# Altium schematic sheet AIRZAI_BASE_BD_REVC Sheet1.SchDoc
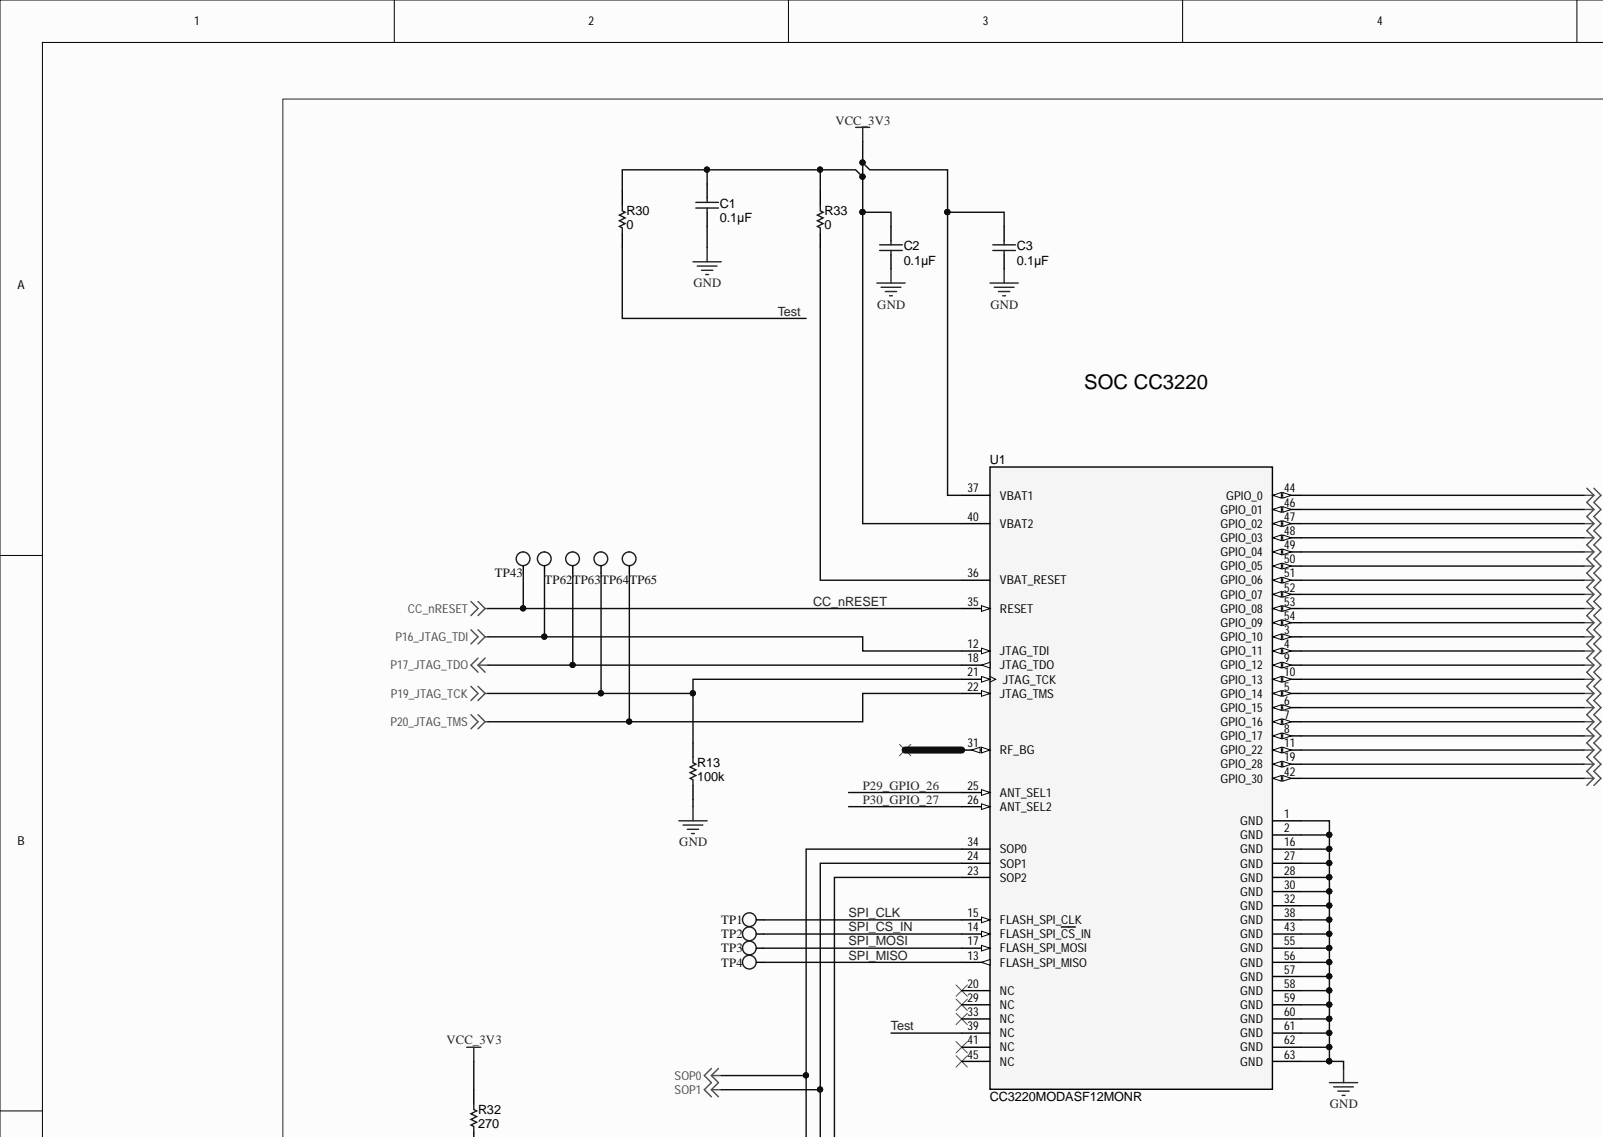
[diagram p0: AIRZAI_BASE_BD_REVC Sheet1.SchDoc, top left]
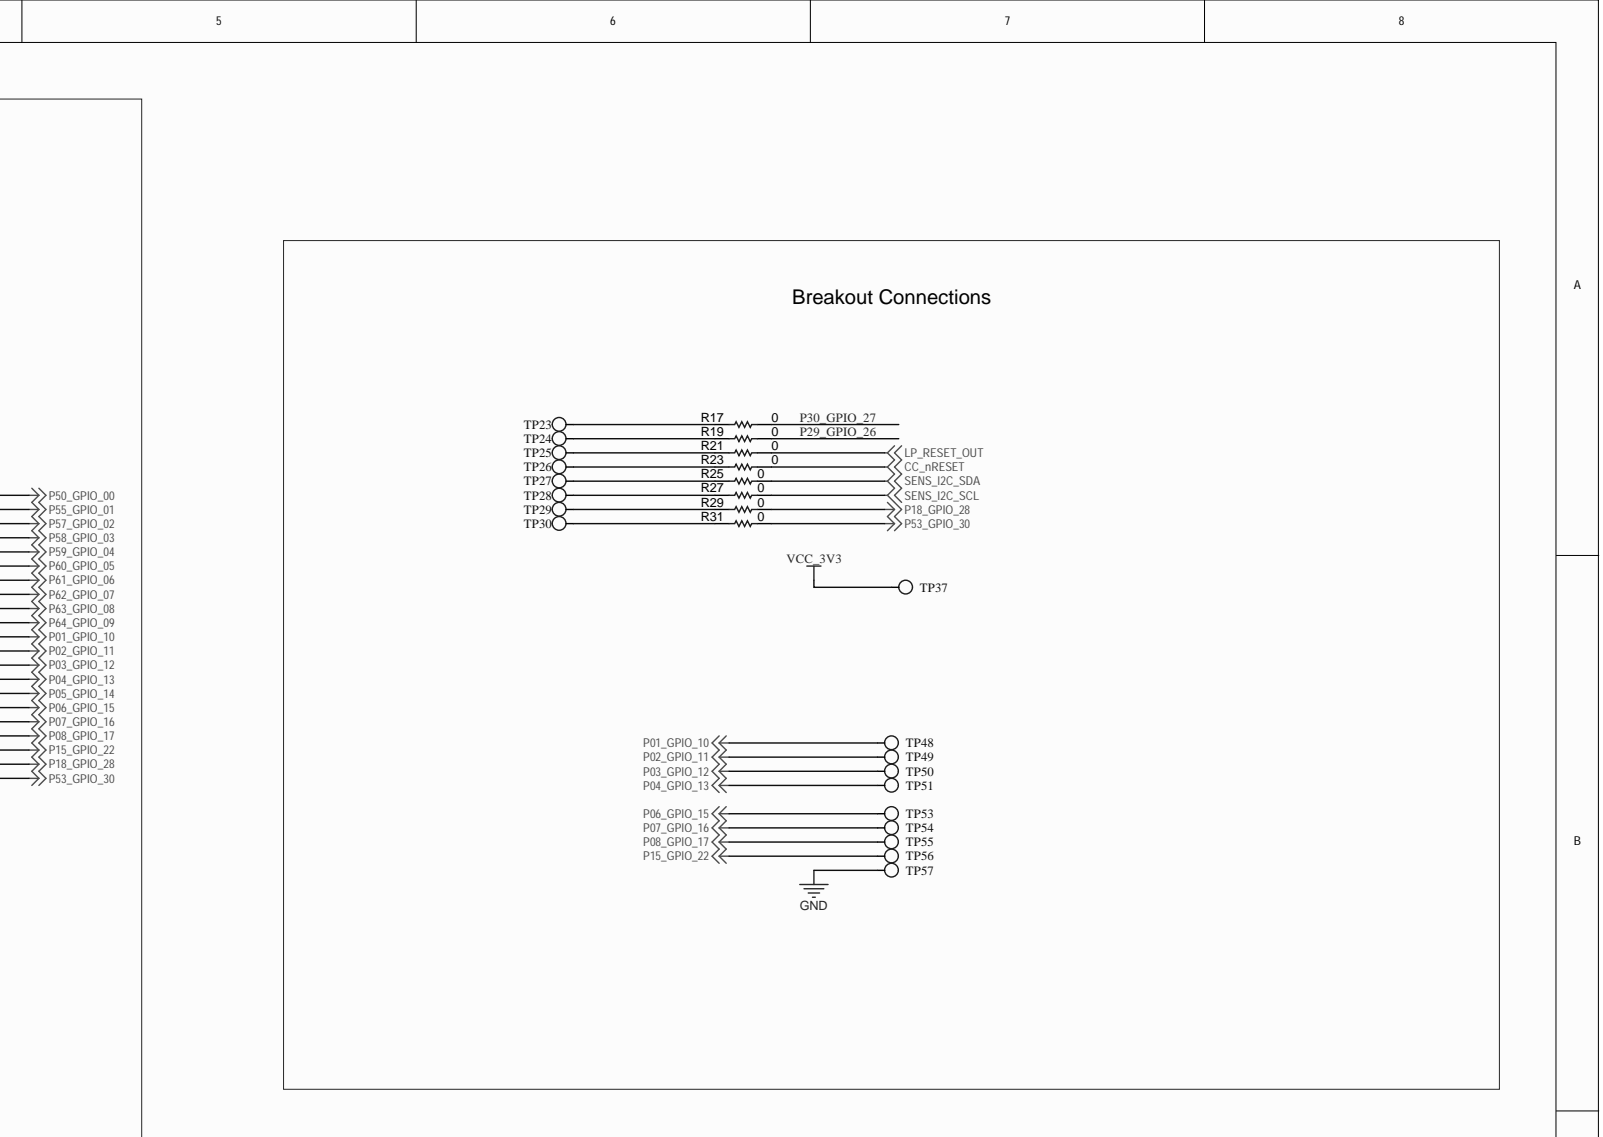
[diagram p1: AIRZAI_BASE_BD_REVC Sheet1.SchDoc, top right]
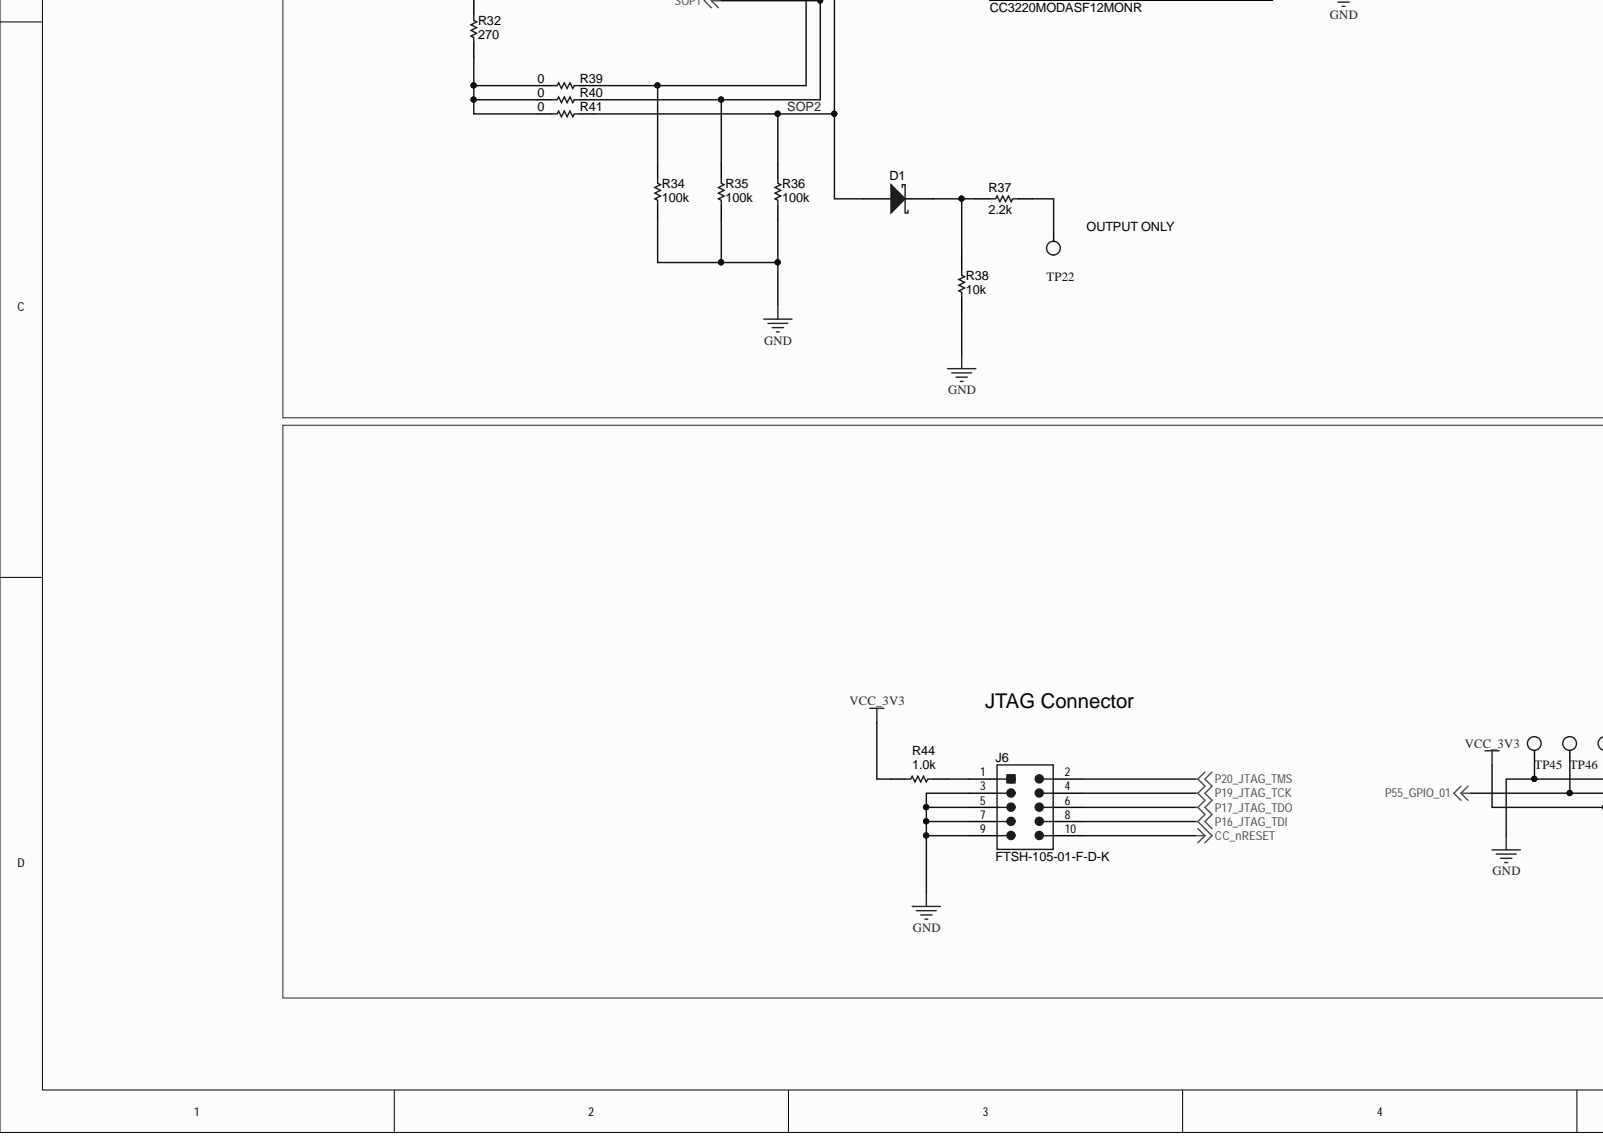
[diagram p2: AIRZAI_BASE_BD_REVC Sheet1.SchDoc, bottom left]
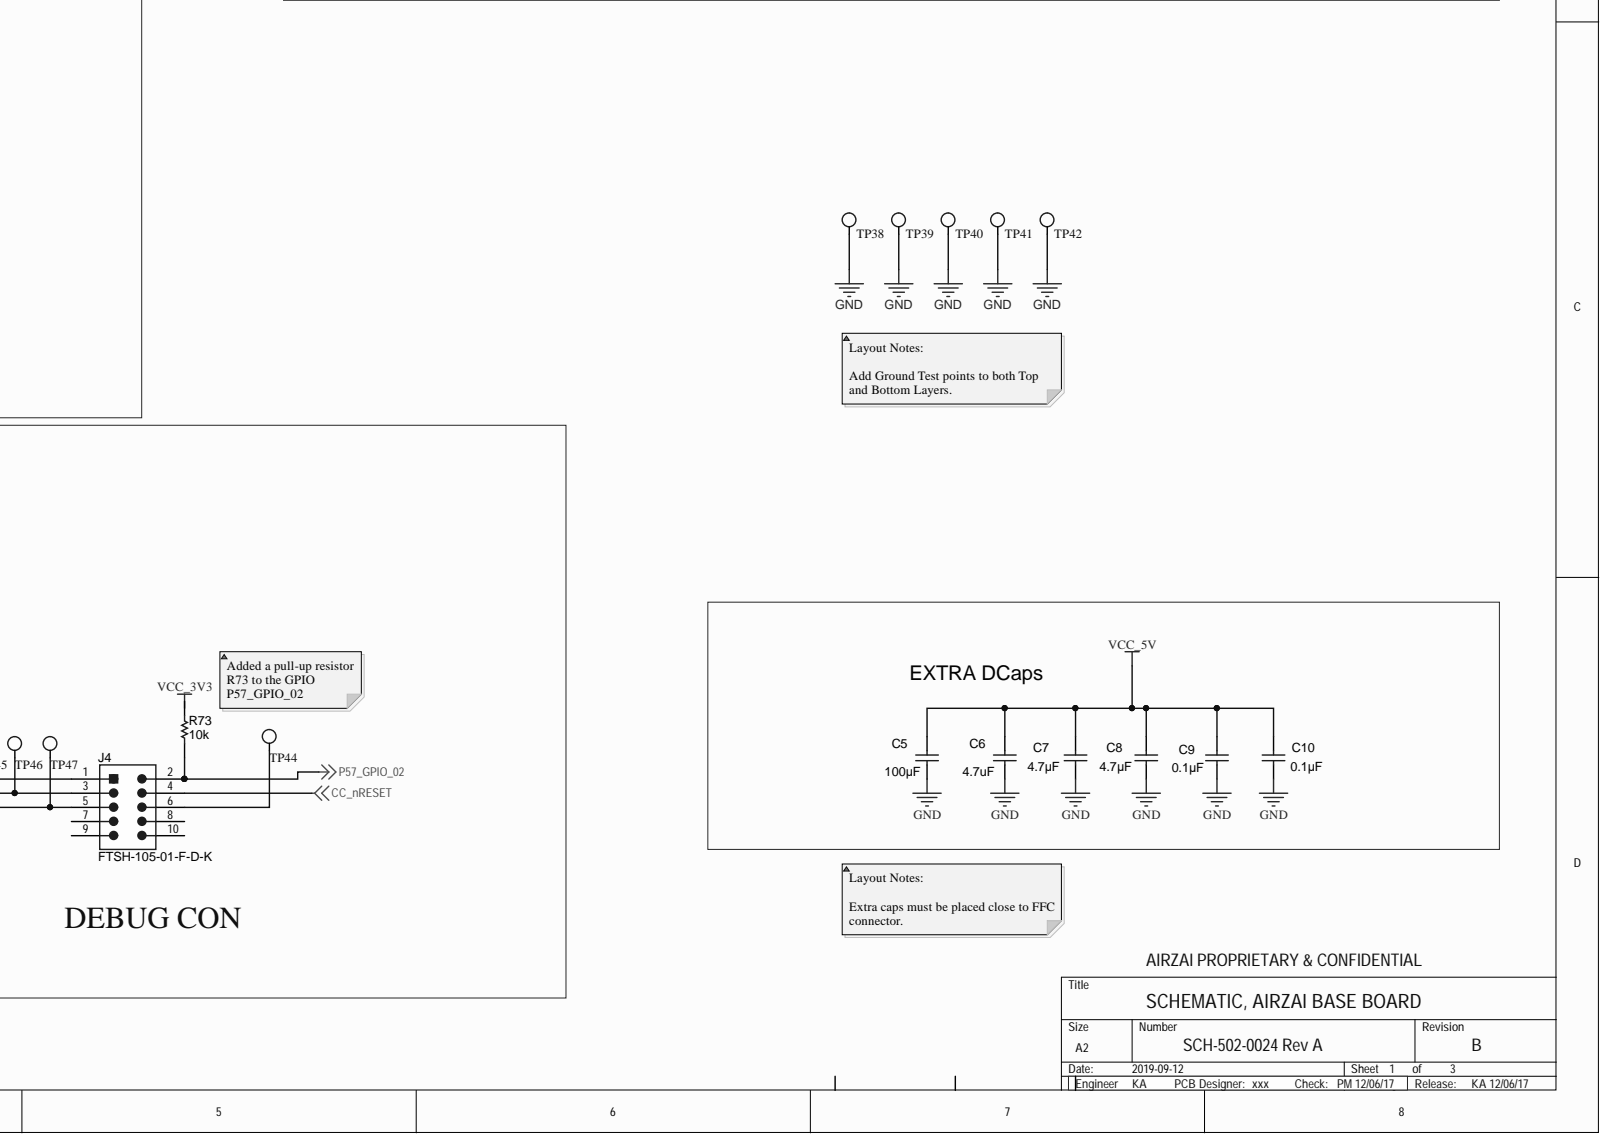
[diagram p3: AIRZAI_BASE_BD_REVC Sheet1.SchDoc, bottom right]

## Parts
C1  ValueDisplayed: 0.1µF  [0402]  (hidden comment: GRM155R61A104KA01D)
C2  ValueDisplayed: 0.1µF  [0402]  (hidden comment: GRM155R61A104KA01D)
C3  ValueDisplayed: 0.1µF  [0402]  (hidden comment: GRM155R61A104KA01D)
C5  ValueDisplayed: 100µF  [1206_180]  (hidden comment: GRM31CR60J107ME39L)
C6  value 4.7uF  [SM0402_BTD.1SM_ACT_H55_CAP_WSS]  (hidden comment: C1005X5R0J475M050BC)
C7  ValueDisplayed: 4.7µF  [SM0402_BTD.1SM_ACT_H55_CAP_WSS]  (hidden comment: C1005X5R0J475M050BC)
C8  ValueDisplayed: 4.7µF  [SM0402_BTD.1SM_ACT_H55_CAP_WSS]  (hidden comment: C1005X5R0J475M050BC)
C9  ValueDisplayed: 0.1µF  [SM0402_BTD.1SM_ACT_H55_CAP_WSS]  (hidden comment: C1005X5R1A104K050BA)
C10  ValueDisplayed: 0.1µF  [SM0402_BTD.1SM_ACT_H55_CAP_WSS]  (hidden comment: C1005X5R1A104K050BA)
D1  CD0603-B00340  [Diode_0603]  (value not drawn)
J4  FTSH-105-01-F-D-K  [Samtec_FTSH-105-01-x-D-K]
J6  FTSH-105-01-F-D-K  [Samtec_FTSH-105-01-x-D-K]
R13  value 100k  [0402]  (hidden comment: CRCW0402100KJNED)
R17  value 0  [0402]  (hidden comment: ERJ-2GE0R00X)
R19  value 0  [0402]  (hidden comment: ERJ-2GE0R00X)
R21  value 0  [0402]  (hidden comment: ERJ-2GE0R00X)
R23  value 0  [0402]  (hidden comment: ERJ-2GE0R00X)
R25  value 0  [0402]  (hidden comment: ERJ-2GE0R00X)
R27  value 0  [0402]  (hidden comment: ERJ-2GE0R00X)
R29  value 0  [0402]  (hidden comment: ERJ-2GE0R00X)
R30  value 0  [0603]  (hidden comment: RC0603JR-070RL)
R31  value 0  [0402]  (hidden comment: ERJ-2GE0R00X)
R32  value 270  [0402]  (hidden comment: CRCW0402270RJNED)
R33  value 0  [0603]  (hidden comment: RC0603JR-070RL)
R34  value 100k  [0402]  (hidden comment: CRCW0402100KJNED)
R35  value 100k  [0402]  (hidden comment: CRCW0402100KJNED)
R36  value 100k  [0402]  (hidden comment: CRCW0402100KJNED)
R37  value 2.2k  [0402]  (hidden comment: CRCW04022K20JNED)
R38  value 10k  [0402]  (hidden comment: CRCW040210K0JNED)
R39  value 0  [0603]  (hidden comment: RC0603JR-070RL)
R40  value 0  [0603]  (hidden comment: RC0603JR-070RL)
R41  value 0  [0603]  (hidden comment: RC0603JR-070RL)
R44  value 1.0k  [0402]  (hidden comment: CRCW04021K00JNED)
R73  value 10k  [0402]  (hidden comment: CRCW040210K0JNED)
TP1  WIREPT  [TP_0.9MM]  (value not drawn)
TP2  WIREPT  [TP_0.9MM]  (value not drawn)
TP3  WIREPT  [TP_0.9MM]  (value not drawn)
TP4  WIREPT  [TP_0.9MM]  (value not drawn)
TP22  WIREPT  [TP_0.9MM]  (value not drawn)
TP23  WIREPT  [TP_0.9MM]  (value not drawn)
TP24  WIREPT  [TP_0.9MM]  (value not drawn)
TP25  WIREPT  [TP_0.9MM]  (value not drawn)
TP26  WIREPT  [TP_0.9MM]  (value not drawn)
TP27  WIREPT  [TP_0.9MM]  (value not drawn)
TP28  WIREPT  [TP_0.9MM]  (value not drawn)
TP29  WIREPT  [TP_0.9MM]  (value not drawn)
TP30  WIREPT  [TP_0.9MM]  (value not drawn)
TP37  WIREPT  [TP_0.9MM]  (value not drawn)
TP38  WIREPT  [TP_0.9MM]  (value not drawn)
TP39  WIREPT  [TP_0.9MM]  (value not drawn)
TP40  WIREPT  [TP_0.9MM]  (value not drawn)
TP41  WIREPT  [TP_0.9MM]  (value not drawn)
TP42  WIREPT  [TP_0.9MM]  (value not drawn)
TP43  WIREPT  [TP_0.9MM]  (value not drawn)
TP44  WIREPT  [TP_0.9MM]  (value not drawn)
TP45  WIREPT  [TP_0.9MM]  (value not drawn)
TP46  WIREPT  [TP_0.9MM]  (value not drawn)
TP47  WIREPT  [TP_0.9MM]  (value not drawn)
TP48  WIREPT  [TP_0.9MM]  (value not drawn)
TP49  WIREPT  [TP_0.9MM]  (value not drawn)
TP50  WIREPT  [TP_0.9MM]  (value not drawn)
TP51  WIREPT  [TP_0.9MM]  (value not drawn)
TP53  WIREPT  [TP_0.9MM]  (value not drawn)
TP54  WIREPT  [TP_0.9MM]  (value not drawn)
TP55  WIREPT  [TP_0.9MM]  (value not drawn)
TP56  WIREPT  [TP_0.9MM]  (value not drawn)
TP57  WIREPT  [TP_0.9MM]  (value not drawn)
TP62  WIREPT  [TP_0.9MM]  (value not drawn)
TP63  WIREPT  [TP_0.9MM]  (value not drawn)
TP64  WIREPT  [TP_0.9MM]  (value not drawn)
TP65  WIREPT  [TP_0.9MM]  (value not drawn)
U1  CC3220MODASF12MONR  [MON0063A]

## Nets
GND: C1.2 C2.2 C3.2 C5.2 C6.2 C7.2 C8.2 C9.2 C10.2 J4.1 J6.3 J6.5 J6.7 J6.9 R13.2 R34.1 R35.2 R36.2 R38.2 TP38.1 TP39.1 TP40.1 TP41.1 TP42.1 TP45.1 TP57.1 U1.1(GND) U1.2(GND) U1.16(GND) U1.27(GND) U1.28(GND) U1.30(GND) U1.32(GND) U1.38(GND) U1.43(GND) U1.55(GND) U1.56(GND) U1.57(GND) U1.58(GND) U1.59(GND) U1.60(GND) U1.61(GND) U1.62(GND) U1.63(GND)
LP_RESET_OUT: R21.2
P01_GPIO_10: TP48.1 U1.3(GPIO_10)
P02_GPIO_11: TP49.1 U1.4(GPIO_11)
P03_GPIO_12: TP50.1 U1.9(GPIO_12)
P04_GPIO_13: TP51.1 U1.10(GPIO_13)
P05_GPIO_14: U1.5(GPIO_14)
P06_GPIO_15: TP53.1 U1.6(GPIO_15)
P07_GPIO_16: TP54.1 U1.7(GPIO_16)
P08_GPIO_17: TP55.1 U1.8(GPIO_17)
P15_GPIO_22: TP56.1 U1.11(GPIO_22)
P16_JTAG_TDI: J6.8 TP62.1 U1.12(JTAG_TDI)
P17_JTAG_TDO: J6.6 TP63.1 U1.18(JTAG_TDO)
P18_GPIO_28: R29.2 U1.19(GPIO_28)
P19_JTAG_TCK: J6.4 R13.1 TP64.1 U1.21(JTAG_TCK)
P20_JTAG_TMS: J6.2 TP65.1 U1.22(JTAG_TMS)
P50_GPIO_00: U1.44(GPIO_0)
P53_GPIO_30: R31.2 U1.42(GPIO_30)
P55_GPIO_01: J4.3 TP46.1 U1.46(GPIO_01)
P57_GPIO_02: J4.2 R73.2 U1.47(GPIO_02)
P58_GPIO_03: U1.48(GPIO_03)
P59_GPIO_04: U1.49(GPIO_04)
P60_GPIO_05: U1.50(GPIO_05)
P61_GPIO_06: U1.51(GPIO_06)
P62_GPIO_07: U1.52(GPIO_07)
P63_GPIO_08: U1.53(GPIO_08)
P64_GPIO_09: U1.54(GPIO_09)
SENS_I2C_SCL: R27.2
SENS_I2C_SDA: R25.2
SOP0: R34.2 R39.1 U1.34(SOP0)
SOP1: R35.1 R40.1 U1.24(SOP1)
VCC_3V3: C1.1 C2.1 C3.1 J4.5 R30.2 R32.1 R33.2 R44.1 TP37.1 TP47.1 U1.37(VBAT1) U1.40(VBAT2)
VCC_5V: C5.1 C6.1 C7.1 C8.1 C9.1 C10.1
CC_nRESET: J4.4 J6.10 R23.2 TP43.1 U1.35(RESET)
P29_GPIO_26: R19.2 U1.25(ANT_SEL1)
P30_GPIO_27: R17.2 U1.26(ANT_SEL2)
SOP2: D1.2 R36.1 R41.1 U1.23(SOP2)
SPI_CLK: TP1.1 U1.15(FLASH_SPI_CLK)
SPI_CS_IN: TP2.1 U1.14(FLASH_SPI_CS_IN)
SPI_MISO: TP4.1 U1.13(FLASH_SPI_MISO)
SPI_MOSI: TP3.1 U1.17(FLASH_SPI_MOSI)
Test: R30.1 U1.39(NC)
NetD1_1: D1.1 R37.1 R38.1
NetJ4_6: J4.6 TP44.1
NetJ4_7: J4.7
NetJ4_8: J4.8
NetJ4_9: J4.9
NetJ4_10: J4.10
NetJ6_1: J6.1 R44.2
NetR17_1: R17.1 TP23.1
NetR19_1: R19.1 TP24.1
NetR21_1: R21.1 TP25.1
NetR23_1: R23.1 TP26.1
NetR25_1: R25.1 TP27.1
NetR27_1: R27.1 TP28.1
NetR29_1: R29.1 TP29.1
NetR31_1: R31.1 TP30.1
NetR32_2: R32.2 R39.2 R40.2 R41.2
NetR33_1: R33.1 U1.36(VBAT_RESET)
NetR37_2: R37.2 TP22.1
NetR73_1: R73.1
NetU1_20: U1.20(NC)
NetU1_29: U1.29(NC)
NetU1_31: U1.31(RF_BG)
NetU1_33: U1.33(NC)
NetU1_41: U1.41(NC)
NetU1_45: U1.45(NC)

## Library data of the parts
C1-C3 = GRM155R61A104KA01D: CAP, CERM, 0.1 µF, 10 V, +/- 10%, X5R, 0402; Manufacturer: MuRata; AEC Category: Q200; Cap_F: 0.0000001; CompType: Capacitor; Polarized: N; SizeArea_mm2: 0.5; SizeAreaWB_mm2: 3; SizeX_mm: 1; SizeZ_mm: 0.6; TaMax_C: 85; TaMin_C: -55; Tolerance_%: 10; U/M: EA; Value: 0.1uF; Value1: Unknown; Value2: 10V; AEC Qualified: ?; Cap_Case: 0402; Component Kind: Standard; ComponentLink1Description: Datasheet link; ComponentLink1URL: http://search.murata.co.jp/Ceramy/PnsearchViewAction.do?HnOFG=ON&HnNFG=ON&SEL_CNT=50&sLang=en&sKey=GRM155R61A104KA01D&x=9&y=9&search=startwith; LatestRevisionDate: 2016-08-14; Library Name: Capacitor_Ceramic.IntLib; MountType: SMT; PackageReference: 0402; PartNumber: GRM155R61A104KA01D; Published: 2008-06-19; RoHS: Y; Signal Integrity: Cap; Simulation: Cap; SizeY_mm: 0.5; Supplier 1: Digi-Key; Supplier 2: Mouser; Supplier 3: Farnell; Supplier Part Number 1: 490-1318-1-ND; Supplier Part Number 2: 81-GRM155R61A104KA01; Supplier Part Number 3: 1828862; SymbolVersion: 2008-07-09; Technology: Ceramic; TempCo: X5R; VDC_V: 10; Publisher: Texas Instruments
C5 = GRM31CR60J107ME39L: CAP, CERM, 100 µF, 6.3 V, +/- 20%, X5R, 1206; Manufacturer: MuRata; AEC Category: Q200; Cap_Case: 1206; Cap_F: 0.0001; CompType: Capacitor; ESR_ohm: 0.003; Polarized: N; SizeArea_mm2: 5.12; SizeAreaWB_mm2: 10.92; SizeX_mm: 3.2; SizeY_mm: 1.6; SizeZ_mm: 1.8; TaMax_C: 85; TaMin_C: -55; Tolerance_%: 20; U/M: EA; Value: 100uF; Value1: 0.003 ohm; Value2: 6.3V; VDC_V: 6.3; AEC Qualified: ?; Component Kind: Standard; ComponentLink1Description: Datasheet link; ComponentLink1URL: http://search.murata.co.jp/Ceramy/PnsearchViewAction.do?HnOFG=ON&HnNFG=ON&SEL_CNT=50&sLang=en&sKey=GRM31CR60J107ME39L&x=9&y=9&search=startwith; LatestRevisionDate: 2016-07-02; Library Name: Capacitor_Ceramic.IntLib; MountType: SMT; PackageReference: 1206; PartNumber: GRM31CR60J107ME39L; Published: 2008-06-19; RoHS: Y; Signal Integrity: Cap; Simulation: Cap; Supplier 1: Digi-Key; Supplier 2: Mouser; Supplier 3: Farnell; Supplier Part Number 1: 490-4539-1-ND; Supplier Part Number 2: 81-GRM31CR60J107ME9L; Supplier Part Number 3: 1735535; SymbolVersion: 2008-07-09; Technology: Ceramic; TempCo: X5R; Publisher: Texas Instruments
C6 = C1005X5R0J475M050BC: CAP, CERM, 4.7 µF, 6.3 V, +/- 20%, X5R, 0402; Manufacturer: TDK; Component Kind: Standard; Library Name: Capacitor_Ceramic.IntLib; PartNumber: C1005X5R0J475M050BC
C7 C8 = C1005X5R0J475M050BC: CAP, CERM, 4.7 µF, 6.3 V, +/- 20%, X5R, 0402; Manufacturer: TDK; Cap_Case: 0402; Cap_F: 0.0000047; CompType: Capacitor; Polarized: N; TaMax_C: 85; TaMin_C: -55; Tolerance_%: 20; U/M: EA; Value: 4.7uF; Value1: Unknown; Value2: 6.3V; VDC_V: 6.3; Component Kind: Standard; ComponentLink1Description: Datasheet link; ComponentLink1URL: http://www.tdk.com/pdf/TDKMLCCCapRange.pdf; LatestRevisionDate: 2015-02-23; Library Name: Capacitor_Ceramic.IntLib; MountType: SMT; PackageReference: 0402; PartNumber: C1005X5R0J475M050BC; Published: 2008-06-19; RoHS: Y; Supplier 1: Digi-Key; Supplier 2: Farnell; Supplier 3: Newark; Supplier Part Number 1: 445-7395-1-ND; Supplier Part Number 2: 1962109; Supplier Part Number 3: 48T2906; SymbolVersion: 2008-07-09; Technology: Ceramic; TempCo: X5R; Publisher: Texas Instruments
C9 = C1005X5R1A104K050BA: CAP, CERM, 0.1 µF, 10 V, +/- 10%, X5R, 0402; Manufacturer: TDK; Cap_Case: 0402; Cap_F: 0.0000001; CompType: Capacitor; Polarized: N; TaMax_C: 85; TaMin_C: -55; Tolerance_%: 10; U/M: EA; Value: 0.1uF; Value1: Unknown; Value2: 10V; Component Kind: Standard; ComponentLink1Description: Datasheet link; ComponentLink1URL: http://product.tdk.com/en/catalog/datasheets/mlcc_commercial_general_en.pdf; LatestRevisionDate: 2015-02-23; Library Name: Capacitor_Ceramic.IntLib; MountType: SMT; PackageReference: 0402; PartNumber: C1005X5R1A104K050BA; Published: 2008-06-19; RoHS: Y; Supplier 1: Digi-Key; Supplier 2: Mouser; Supplier 3: Farnell; Supplier Part Number 1: 445-1265-1-ND; Supplier Part Number 2: 810-C1005X5R1A104K; Supplier Part Number 3: 2368215; SymbolVersion: 2008-07-09; Technology: Ceramic; TempCo: X5R; VDC_V: 10; Publisher: Texas Instruments
C10 = C1005X5R1A104K050BA: CAP, CERM, 0.1 µF, 10 V, +/- 10%, X5R, 0402; Manufacturer: TDK; Cap_Case: SM0402_BTD.1SM_ACT; Cap_F: 0.0000001; CompType: Capacitor; Polarized: N; TaMax_C: 85; TaMin_C: -55; Tolerance_%: 10; U/M: EA; Value: 0.1uF; Value1: Unknown; Value2: 10V; Component Kind: Standard; ComponentLink1Description: Datasheet link; ComponentLink1URL: http://product.tdk.com/en/catalog/datasheets/mlcc_commercial_general_en.pdf; LatestRevisionDate: 2015-02-23; Library Name: Capacitor_Ceramic.IntLib; MountType: SMT; PackageReference: 0402; PartNumber: C1005X5R1A104K050BA; Published: 2008-06-19; RoHS: Y; Supplier 1: Digi-Key; Supplier 2: Mouser; Supplier 3: Farnell; Supplier Part Number 1: 445-1265-1-ND; Supplier Part Number 2: 810-C1005X5R1A104K; Supplier Part Number 3: 2368215; SymbolVersion: 2008-07-09; Technology: Ceramic; TempCo: X5R; VDC_V: 10; Publisher: Texas Instruments
D1 = CD0603-B00340: Diode, Schottky, 45 V, 0.03 A, 0603 Diode; Manufacturer: Bourns; AEC Category: Q101; Io_A: 0.03; SizeArea_mm2: 1.53; SizeAreaWB_mm2: 5.13; SizeX_mm: 1.7; SizeY_mm: 0.9; SizeZ_mm: 0.75; TJmax_C: 125; U/M: EA; Value: 45V; Value1: 0.03A; Value2: 0.37V; Vf_V: 0.37; Vr_V: 45; AEC Qualified: ?; Component Kind: Standard; ComponentLink1Description: Datasheet link; ComponentLink1URL: http://www.bourns.com/pdfs/SBCD0603_1005.pdf; CompType: Diode; LatestRevisionDate: 2016-07-03; Library Name: Diode.IntLib; MountType: SMT; PackageReference: 0603 Diode; PartNumber: CD0603-B00340; Published: 2008-06-26; RoHS: Y; Signal Integrity: Diode; Supplier 1: Mouser; Supplier 2: Farnell; Supplier Part Number 1: 652-CD0603-B00340; Supplier Part Number 2: 1456534; SymbolVersion: 2008-12-08; Type: Schottky; Publisher: Texas Instruments
J4 J6 = FTSH-105-01-F-D-K: Header, 1.27 mm, 5x2, Gold, TH; Manufacturer: Samtec; Gender: Male; Pitch_mm: 1.27; Row_Count: 2; Shrouded: Y; SymbolCreator: a0412235; Color: Black; Component Kind: Standard; ComponentLink1Description: Datasheet URL; ComponentLink1URL: https://www.samtec.com/ftppub/cpdf/FTSH-1XX-XX-XXX-D-XX-MKT.pdf; CompType: Header; ContactFinish: Gold; LatestRevisionDate: 2016-07-01; Library Name: Connectors_Samtec.IntLib; MountType: TH; Orientation: Vertical; PackageReference: Header, 1.27 mm, 5x2, TH; PartNumber: FTSH-105-01-F-D-K; PinCount: 10; RoHS: Y; RowSpacing_mm: 1.27; Supplier 1: Digi-Key; Supplier Part Number 1: FTSH-105-01-F-D-K-ND; SymbolVersion: 2013-12-22; Publisher: Texas Instruments
R13 R34-R36 = CRCW0402100KJNED: RES, 100 k, 5%, 0.063 W, 0402; Manufacturer: Vishay-Dale; AEC Category: Q200; CompType: Resistor; EIA_Code: E24; Power_W: 0.063; Res_ohm: 100000; SizeArea_mm2: 0.5; SizeX_mm: 1; SizeZ_mm: 0.6; TempCo: 200 ppm/C; Tolerance_%: 5; U/M: EA; Value2: 0.063W; VDC_V: 50; AEC Qualified: ?; Component Kind: Standard; ComponentLink1Description: Datasheet link; ComponentLink1URL: http://www.vishay.com/docs/20035/dcrcwe3.pdf; LatestRevisionDate: 2016-07-01; Library Name: Resistor_0402.IntLib; MountType: SMT; PackageReference: 0402; PartNumber: CRCW0402100KJNED; Published: 2008-06-26; RoHS: Y; Signal Integrity: Resistor; Simulation: Resistor; SizeY_mm: 0.5; Supplier 1: Digi-Key; Supplier 2: Mouser; Supplier 3: Farnell; Supplier Part Number 1: 541-100KJCT-ND; Supplier Part Number 2: 71-CRCW0402J-100K-E3; Supplier Part Number 3: 1652738; SymbolVersion: 2009-05-29; Technology: Thick Film; Value1: 5%; Value_Code: 100k; ValueDisplayed: 100k; Publisher: Texas Instruments
R17 R19 R21 R23 R25 R27 R29 R31 = ERJ-2GE0R00X: RES, 0, 5%, 0.063 W, 0402; Manufacturer: Panasonic; AEC Category: Q200; CompType: Resistor; EIA_Code: E24; Power_W: 0.063; SizeArea_mm2: 0.5; SizeX_mm: 1; SizeZ_mm: 0.6; TempCo: 600 ppm/C; Tolerance_%: 5; U/M: EA; Value2: 0.063W; Value_Code: 0000; VDC_V: 50; AEC Qualified: ?; Component Kind: Standard; ComponentLink1Description: Datasheet link; ComponentLink1URL: http://www.panasonic.com/industrial/components/pdf/AOA0000CE1.pdf; LatestRevisionDate: 2016-07-01; Library Name: Resistor_0402.IntLib; MountType: SMT; PackageReference: 0402; PartNumber: ERJ-2GE0R00X; Published: 2008-06-26; Res_ohm: 0; RoHS: Y; Signal Integrity: Resistor; Simulation: Resistor; SizeY_mm: 0.5; Supplier 1: Digi-Key; Supplier 2: Mouser; Supplier 3: Farnell; Supplier Part Number 1: P0.0JCT-ND; Supplier Part Number 2: 667-ERJ-2GE0R00X; Supplier Part Number 3: 2059190; SymbolVersion: 2009-05-29; Technology: Thick Film; Value1: 5%; ValueDisplayed: 0; Publisher: Texas Instruments
R30 R33 R39-R41 = ERJ-2GE0R00X: RES, 0, 5%, 0.1 W, 0603; Manufacturer: Yageo; Power Rating: 100mW; Resistance: 0mR; Temperature Coefficient: 200ppm/°C; Tolerance: 1%; Value2: 0.1W; Value_Code: 0000; VDC_V: 50; Voltage Rating: 50V; Case/Package: 0603; Case Code (Imperial): 0603; Case Code (Metric): 1608; Component Kind: Standard; ComponentLink1Description: Datasheet link; ComponentLink1URL: http://www.panasonic.com/industrial/components/pdf/AOA0000CE1.pdf; ComponentLink2Description: http://www.farnell.com/datasheets/1868787.pdf?src-supplier=Element14; ComponentLink2URL: http://www.farnell.com/datasheets/1868787.pdf?src-supplier=Element14; ComponentLink3Description: https://www.verical.com/server-webapp/html/getDatasheet?partID=329462&src-supplier=Verical; ComponentLink3URL: https://www.verical.com/server-webapp/html/getDatasheet?partID=329462&src-supplier=Verical; ComponentLink4Description: http://www.yageo.com/documents/recent/PYu-RC_Group_51_RoHS_L_9.pdf?src-supplier=Mouser; ComponentLink4URL: http://www.yageo.com/documents/recent/PYu-RC_Group_51_RoHS_L_9.pdf?src-supplier=Mouser; ComponentLink5Description: http://www.farnell.com/datasheets/443971.pdf?src-supplier=Element14; ComponentLink5URL: http://www.farnell.com/datasheets/443971.pdf?src-supplier=Element14; ComponentLink6Description: http://documents.rs-components.com/techlib://itc_doclist_GB.htm?stockno=6515918&src-supplier=RSComponents; ComponentLink6URL: http://documents.rs-components.com/techlib://itc_doclist_GB.htm?stockno=6515918&src-supplier=RSComponents; Composition: Thick Film; Contact Plating: Tin,Matte; Depth: 0.8mm; Height: 0.45mm; Lead Free: Lead Free; Length: 1.6mm; Library Name: Resistor_0402.IntLib; Manufacturer Part Number: RC0603JR-070RL; Max Operating Temperature: 155°C; Military Standard: Not; Min Operating Temperature: -55°C; Number of Pins: 2; Package Quantity: 5000; Packaging: Tape and Reel; PartNumber: RC0603JR-070RL; Pricing 1: 1=0.1, 10=0.021, 25=0.0152, 50=0.0114, 100=0.0084, 250=0.00644, 500=0.00514, 1000=0.00379 (USD); Radiation Hardening: No; REACH SVHC: No SVHC; Signal Integrity: Resistor; Simulation: Resistor; Stock 1: 47590704; Supplier 1: Digi-Key; Supplier Part Number 1: 311-0.0GRDKR-ND; Termination: SMD/SMT; Value1: 5%; ValueDisplayed: 0; Voltage Rating (DC): 50V; Width: 0.8mm
R32 = CRCW0402270RJNED: RES, 270, 5%, 0.063 W, 0402; Manufacturer: Vishay-Dale; AEC Category: Q200; CompType: Resistor; EIA_Code: E24; Power_W: 0.063; SizeArea_mm2: 0.5; SizeX_mm: 1; SizeZ_mm: 0.6; TempCo: 200 ppm/C; Tolerance_%: 5; U/M: EA; Value2: 0.063W; Value_Code: 270R; VDC_V: 50; AEC Qualified: ?; Component Kind: Standard; ComponentLink1Description: Datasheet link; ComponentLink1URL: http://www.vishay.com/docs/20035/dcrcwe3.pdf; LatestRevisionDate: 2016-07-01; Library Name: Resistor_0402.IntLib; MountType: SMT; PackageReference: 0402; PartNumber: CRCW0402270RJNED; Published: 2008-06-26; Res_ohm: 270; RoHS: Y; Signal Integrity: Resistor; Simulation: Resistor; SizeY_mm: 0.5; Supplier 1: Digi-Key; Supplier 2: Mouser; Supplier 3: Farnell; Supplier Part Number 1: 541-270JCT-ND; Supplier Part Number 2: 71-CRCW0402J-270-E3; Supplier Part Number 3: 1514691; SymbolVersion: 2009-05-29; Technology: Thick Film; Value1: 5%; ValueDisplayed: 270; Publisher: Texas Instruments
R37 = CRCW04022K20JNED: RES, 2.2 k, 5%, 0.063 W, 0402; Manufacturer: Vishay-Dale; AEC Category: Q200; CompType: Resistor; EIA_Code: E24; Power_W: 0.063; Res_ohm: 2200; SizeArea_mm2: 0.5; SizeX_mm: 1; SizeZ_mm: 0.6; TempCo: 200 ppm/C; Tolerance_%: 5; U/M: EA; Value2: 0.063W; Value_Code: 2k20; VDC_V: 50; AEC Qualified: ?; Component Kind: Standard; ComponentLink1Description: Datasheet link; ComponentLink1URL: http://www.vishay.com/docs/20035/dcrcwe3.pdf; LatestRevisionDate: 2016-07-01; Library Name: Resistor_0402.IntLib; MountType: SMT; PackageReference: 0402; PartNumber: CRCW04022K20JNED; Published: 2008-06-26; RoHS: Y; Signal Integrity: Resistor; Simulation: Resistor; SizeY_mm: 0.5; Supplier 1: Digi-Key; Supplier 2: Mouser; Supplier 3: Farnell; Supplier Part Number 1: 541-2.2KJCT-ND; Supplier Part Number 2: 71-CRCW0402J-2.2K-E3; Supplier Part Number 3: 1514678; SymbolVersion: 2009-05-29; Technology: Thick Film; Value1: 5%; ValueDisplayed: 2.2k; Publisher: Texas Instruments
R38 R73 = CRCW040210K0JNED: RES, 10 k, 5%, 0.063 W, 0402; Manufacturer: Vishay-Dale; AEC Category: Q200; CompType: Resistor; EIA_Code: E24; Power_W: 0.063; Res_ohm: 10000; SizeArea_mm2: 0.5; SizeX_mm: 1; SizeZ_mm: 0.6; TempCo: 200 ppm/C; Tolerance_%: 5; U/M: EA; Value2: 0.063W; Value_Code: 10k0; VDC_V: 50; AEC Qualified: ?; Component Kind: Standard; ComponentLink1Description: Datasheet link; ComponentLink1URL: http://www.vishay.com/docs/20035/dcrcwe3.pdf; LatestRevisionDate: 2016-07-01; Library Name: Resistor_0402.IntLib; MountType: SMT; PackageReference: 0402; PartNumber: CRCW040210K0JNED; Published: 2008-06-26; RoHS: Y; Signal Integrity: Resistor; Simulation: Resistor; SizeY_mm: 0.5; Supplier 1: Digi-Key; Supplier 2: Mouser; Supplier 3: Farnell; Supplier Part Number 1: 541-10KJCT-ND; Supplier Part Number 2: 71-CRCW0402J-10K-E3; Supplier Part Number 3: 1514663; SymbolVersion: 2009-05-29; Technology: Thick Film; Value1: 5%; ValueDisplayed: 10k; Publisher: Texas Instruments
R44 = CRCW04021K00JNED: RES, 1.0 k, 5%, 0.063 W, 0402; Manufacturer: Vishay-Dale; AEC Category: Q200; CompType: Resistor; EIA_Code: E24; Power_W: 0.063; Res_ohm: 1000; SizeArea_mm2: 0.5; SizeX_mm: 1; SizeZ_mm: 0.6; TempCo: 200 ppm/C; Tolerance_%: 5; U/M: EA; Value2: 0.063W; Value_Code: 1k00; VDC_V: 50; AEC Qualified: ?; Component Kind: Standard; ComponentLink1Description: Datasheet link; ComponentLink1URL: http://www.vishay.com/docs/20035/dcrcwe3.pdf; LatestRevisionDate: 2016-07-01; Library Name: Resistor_0402.IntLib; MountType: SMT; PackageReference: 0402; PartNumber: CRCW04021K00JNED; Published: 2008-06-26; RoHS: Y; Signal Integrity: Resistor; Simulation: Resistor; SizeY_mm: 0.5; Supplier 1: Digi-Key; Supplier 2: Mouser; Supplier 3: Farnell; Supplier Part Number 1: 541-1.0KJCT-ND; Supplier Part Number 2: 71-CRCW0402J-1.0K-E3; Supplier Part Number 3: 1514656; SymbolVersion: 2009-05-29; Technology: Thick Film; Value1: 5%; ValueDisplayed: 1.0k; Publisher: Texas Instruments
U1 = CC3220MODASF12MONR: SimpleLink Wi-Fi and Internet-of-Things Module Solution, a Single-Chip Wireless MCU, MON0063A (QFM-63); Alternate Manufacturer: Texas Instruments; Alternate PartNumber: XCC3220MODASF12MONR; CompType: IC; SizeArea_mm2: 522.75; SizeAreaWB_mm2: 618.75; SizeX_mm: 25.5; SizeY_mm: 20.5; SizeZ_mm: 2.45; SymbolCreator: Altium; U/M: EA; Component Kind: Standard; ComponentLink1Description: Datasheet Link; ComponentLink1URL: https://sps06.itg.ti.com/sites/KMS/crossdivteams/altium/docs/ComponentDatasheets/t77h533-20_ANT%20module%20dimension_2016-0910.pdf; LatestRevisionDate: 2017-05-12; Manufacturer: Texas Instruments; MountType: SMT; PackageDescription: QFM-63; PackageReference: MON0063A; PartNumber: CC3220MODASF12MONR; SymbolVersion: 2017-05-11; Library Name: TI_Pre_RTM.IntLib; Publisher: Texas Instruments
TP1-TP4 TP22-TP30 TP37-TP51 TP53-TP57 TP62-TP65 = WIREPT: Component Kind: Standard; Library Name: EAGLE_CAD_Library.SchLib
